# Altium schematic sheet 04_POWER.SchDoc(04_POWER)
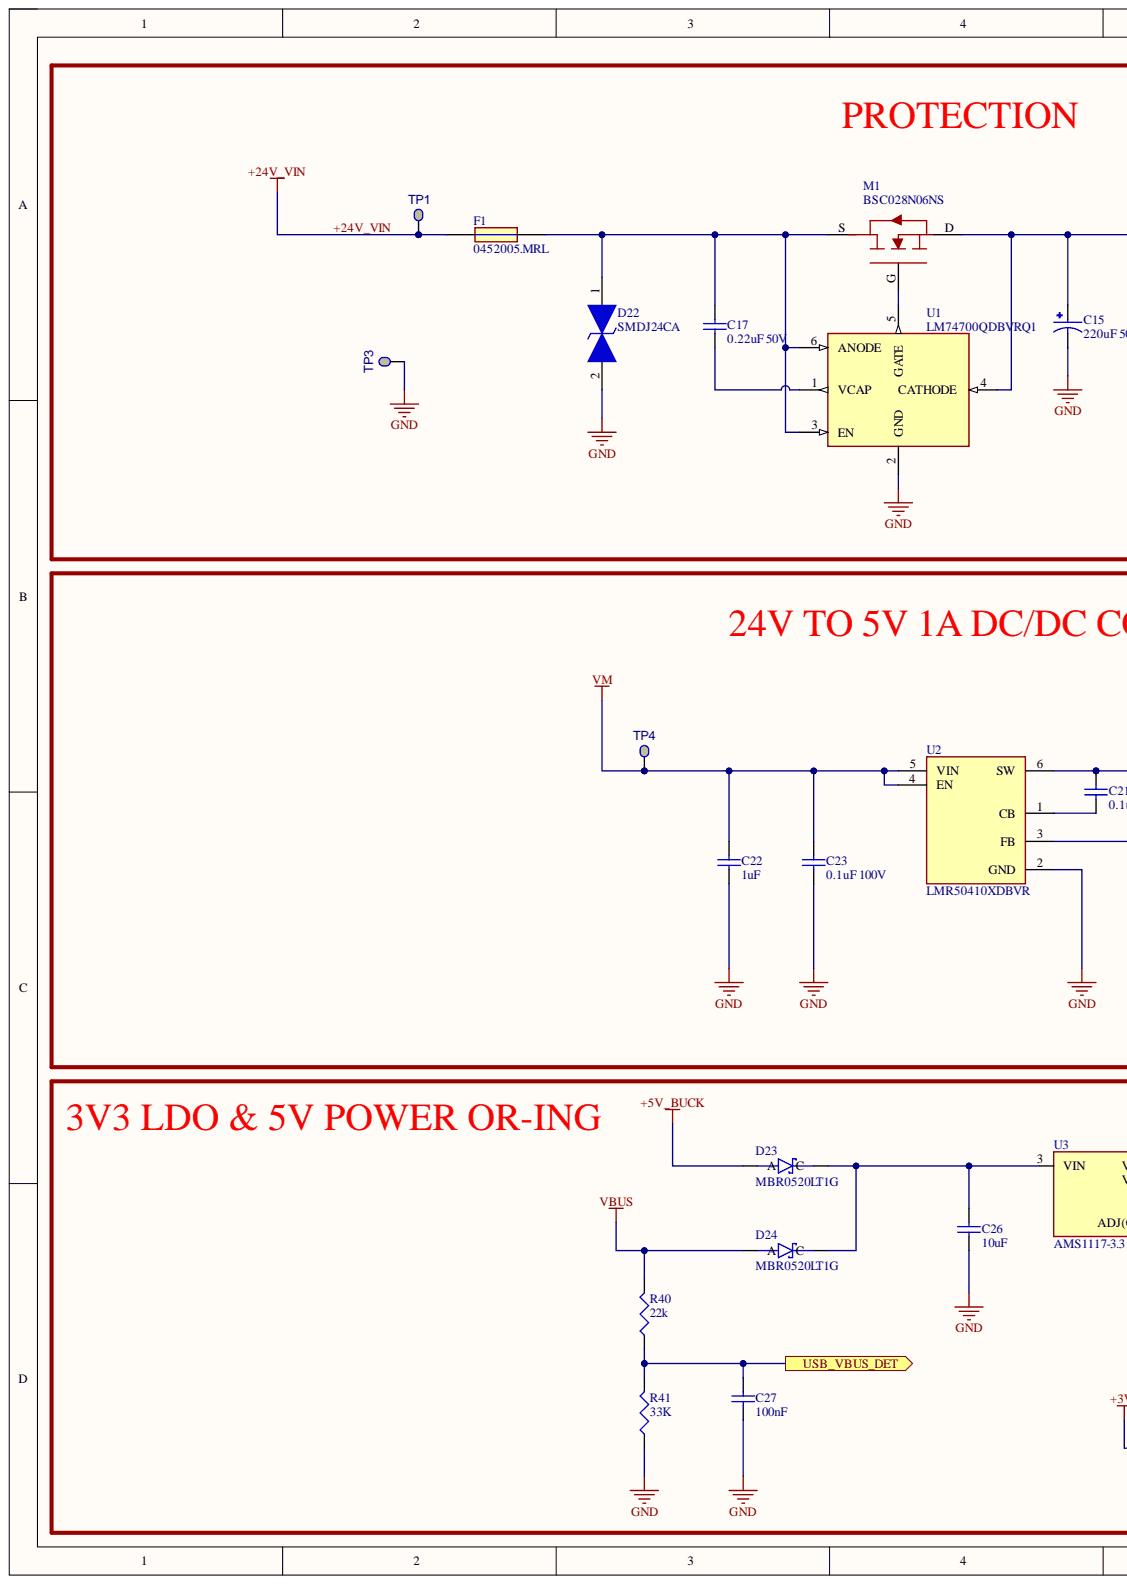
[diagram p0: 04_POWER.SchDoc, left]
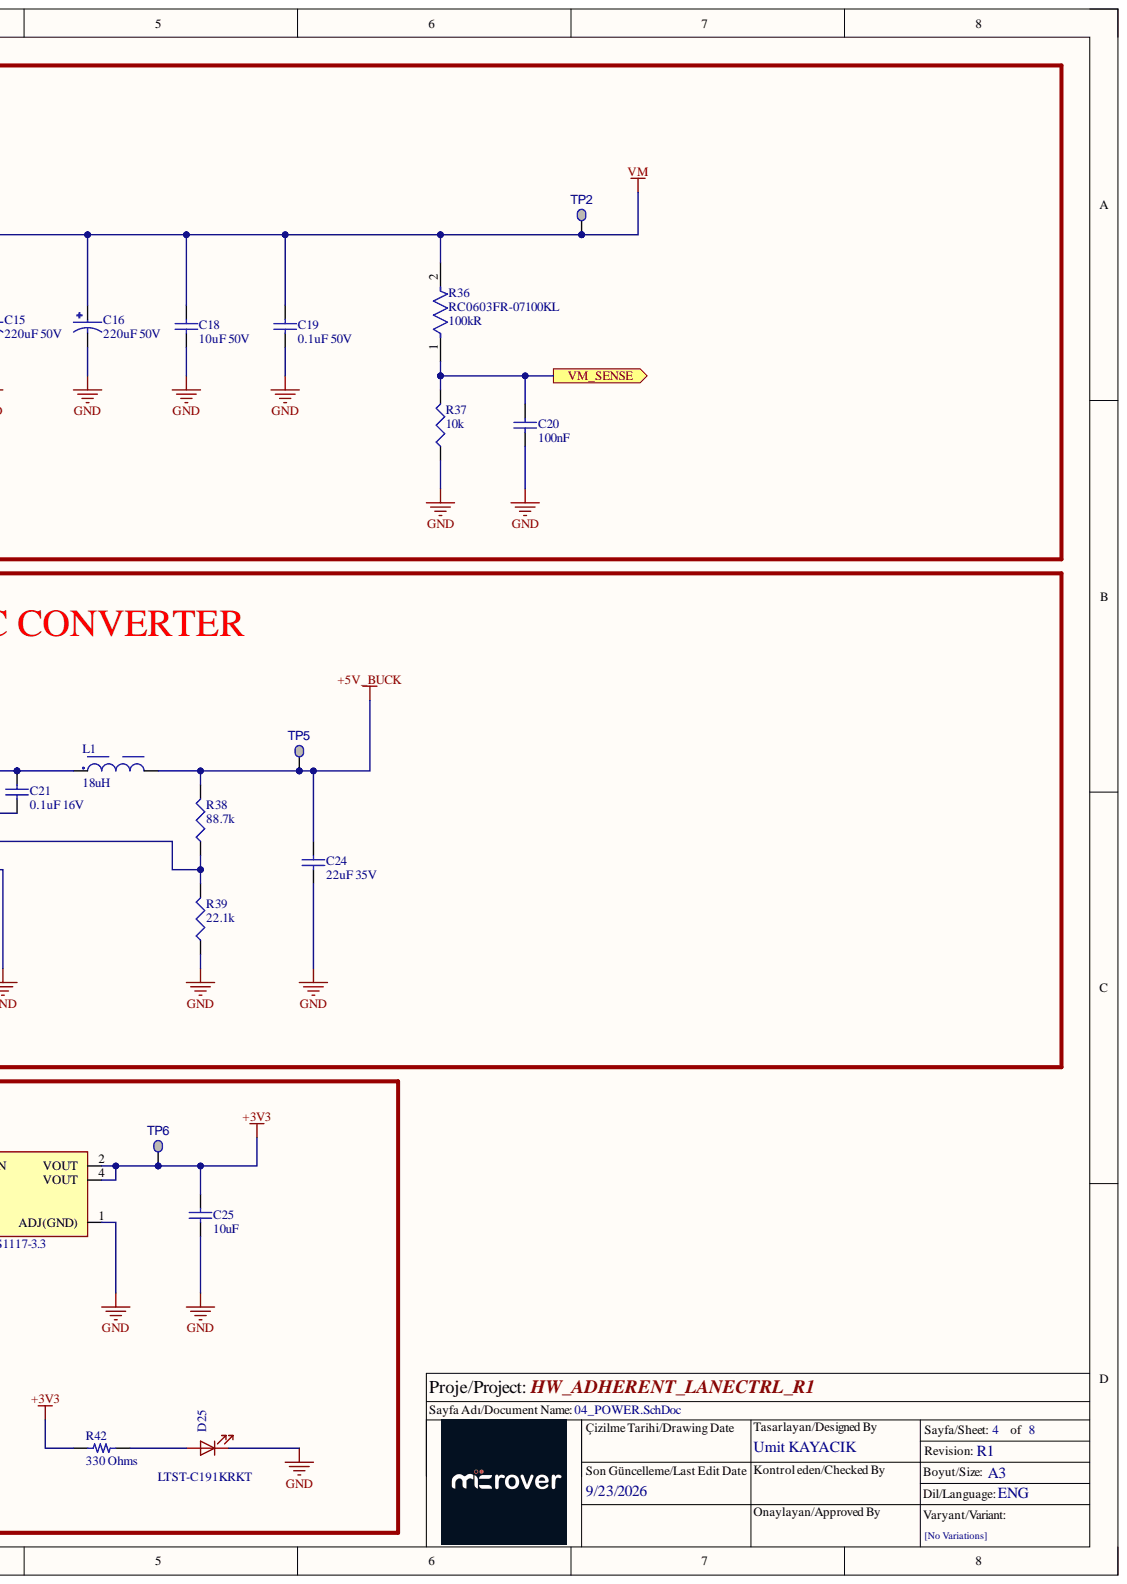
[diagram p1: 04_POWER.SchDoc, right]

## Parts
C15  220uF 50V  [CAP_EEEFT1H221AV]
C16  220uF 50V  [CAP_EEEFT1H221AV]
C17  value 0.22uF 50V  [SMC0603]  (hidden comment: CAP CER 0.22UF 50V X7R 0603)
C18  value 10uF 50V  [SMC1206]  (hidden comment: CAP CER 10UF 50V X5R 1206)
C19  value 0.1uF 50V  [SMC0603]  (hidden comment: CAP CER 0.1UF 50V X7R 0603)
C20  value 100nF  [CAP0603]  (hidden comment: 100nF 25V)
C21  0.1uF 16V  [CAP0603]
C22  1uF  [CAP0603]
C23  0.1uF 100V  [CAP0603]
C24  22uF 35V  [CAP1206]
C25  10uF  [CAP0603]
C26  10uF  [CAP0603]
C27  value 100nF  [CAP0603]  (hidden comment: 100nF 25V)
D22  SMDJ24CA  [DIONM9059X262N]
D23  MBR0520LT1G  [SOD3716X135N]
D24  MBR0520LT1G  [SOD3716X135N]
D25  LTST-C191KRKT  [LED_SMD:LED_0603_1608Metric]
F1  0452005.MRL  [FUSC6127X294N]
L1  18uH  [WE-LQS_6045]
M1  BSC028N06NS  [BSC028N06NS]
R36  RC0603FR-07100KL  Resistance: 100kR  [FP-RC0603-0_55-MFG]
R37  value 10k  [RES0603]  (hidden comment: RES 10K OHM 1% 1/8W 0603)
R38  88.7k  [RES0603]
R39  22.1k  [RES0603]
R40  value 22k  [SMR0603]  (hidden comment: RES 22K OHM 1% 1/10W 0603)
R41  value 33K  [SMR0603]  (hidden comment: RES 33K OHM 1% 1/10W 0603)
R42  330 Ohms  [RES 0603_1608]
TP1  Testpoint  [SMD_Ø1.5mm]  (value not drawn)  (no BOM)
TP2  Testpoint  [SMD_Ø1.5mm]  (value not drawn)  (no BOM)
TP3  Testpoint  [SMD_Ø1.5mm]  (value not drawn)  (no BOM)
TP4  Testpoint  [SMD_Ø1.5mm]  (value not drawn)  (no BOM)
TP5  Testpoint  [SMD_Ø1.5mm]  (value not drawn)  (no BOM)
TP6  Testpoint  [SMD_Ø1.5mm]  (value not drawn)  (no BOM)
U1  LM74700QDBVRQ1  [SOT95P280X145-6N/LM74700QDBVRQ1]
U2  LMR50410XDBVR  [SOT95P280X145-6N/]
U3  AMS1117-3.3  [SOT-223-4_L6.5-W3.5-P2.30-LS7.0-BR]

## Ports
USB_VBUS_DET, VM_SENSE

## Nets
+3V3: C25.2 R42.1 TP6.1 U3.2(VOUT) U3.4(VOUT)
+5V_BUCK: C24.2 D23.A L1.2 R38.2 TP5.1
GND: C15.2 C16.2 C18.1 C19.1 C20.1 C22.1 C23.1 C24.1 C25.1 C26.1 C27.1 D22.2 D25.2 R37.1 R39.1 R41.1 TP3.1 U1.2(GND) U2.2(GND) U3.1(ADJ(GND))
VBUS: D24.A R40.2
VM: C15.1 C16.1 C18.2 C19.2 C22.2 C23.2 M1.D R36.2 TP2.1 TP4.1 U1.4(CATHODE) U2.4(EN) U2.5(VIN)
+24V_VIN: F1.1 TP1.1
USB_VBUS_DET: C27.2 R40.1 R41.2 ; port USB_VBUS_DET
VM_SENSE: C20.2 R36.1 R37.2 ; port VM_SENSE
NetC17_1: C17.1 U1.1(VCAP)
NetC17_2: C17.2 D22.1 F1.2 M1.S U1.3(EN) U1.6(ANODE)
NetC21_1: C21.1 U2.1(CB)
NetC21_2: C21.2 L1.1 U2.6(SW)
NetC26_2: C26.2 D23.C D24.C U3.3(VIN)
NetD25_1: D25.1 R42.2
NetM1_G: M1.G U1.5(GATE)
NetR38_1: R38.1 R39.2 U2.3(FB)

## Library data of the parts
C15 C16 = EEEFT1H221AV: 220 µF 50 V Aluminum Electrolytic Capacitors Radial, Can - SMD 180mOhm @ 100kHz 2000 Hrs @ 105°C; Manufacturer Part Number: EEE-FT1H221AV; MF: Panasonic Electronic Components; Availability: In Stock; Check_prices: https://www.snapeda.com/parts/EEE-FT1H221AV/Panasonic/view-part/5517810/?ref=eda; Component Kind: Standard; Package: RADIAL-2 Panasonic; Price: None; SnapEDA_Link: https://www.snapeda.com/parts/EEE-FT1H221AV/Panasonic/view-part/5517810/?ref=snap; Library Name: HW_ADHERENT_LANECTRL_R1.SchLib
C17 = CAP0603_0.22UF_50V: 0.22 µF ±10% 50V Ceramic Capacitor X7R 0603 (1608 Metric); Manufacturer Part Number: GCM188R71H224KA64D; Order Code: DIGI: 490-5402-2-ND; Component Kind: Standard; Value: 0.22uF 50V; Library Name: HW_ADHERENT_LANECTRL_R1.SchLib
C18 = CAP1206_10UF_50V: 10 µF ±10% 50V Ceramic Capacitor X5R 1206 (3216 Metric); LCSC Manufacturer Part Number: CL31A106KBHNNNE; Order Code: Digi: 1276-2876-2-ND; Component Kind: Standard; LCSC Part Number: C13585; Manufacturer Part Number: CL31A106KBHNNNE; Library Name: HW_ADHERENT_LANECTRL_R1.SchLib
C19 = CAP0603_0.1UF_50V_2: 0.1 µF ±10% 50V Ceramic Capacitor X7R 0603 (1608 Metric); LCSC Manufacturer Part Number: CL10B104KB8NNNC; Manufacturer Part Number: CL10B104KB8NNNL; Order Code: DIGI:1276-CL10B104KB8NNNLTR-ND; Sirius Stock Code: A063-AGC10300001226; Component Kind: Standard; LCSC Part Number: C1591; Library Name: HW_ADHERENT_LANECTRL_R1.SchLib
C20 C27 = CAP0603_100nF: 0.1 µF ±10% 50V Ceramic Capacitor X7R 0603 (1608 Metric); Manufacturer Part Number: CL10B104KA8NNNC; Component Kind: Standard; LCSC Part Number: C1590; Library Name: HW_ADHERENT_LANECTRL_R1.SchLib
C21 = C0603C104M4RACTU: 0.1 µF ±20% 16V Ceramic Capacitor X7R 0603 (1608 Metric); Manifacturer: KEMET; Component Kind: Standard; Supplier: Digi; 399-C0603C104M4RACTUDKR-ND; Library Name: HW_ADHERENT_LANECTRL_R1.SchLib
C22 = MSASU168SB5105MTNA01: CAP CER 1UF 50V X5R 0603; Manufacturer: Taiyo Yuden; Component Kind: Standard; Supplier: 587-MSASU168SB5105MTNA01DKR-ND; Library Name: HW_ADHERENT_LANECTRL_R1.SchLib
C23 = GRM188R72A104KA35D: CAP CER 0.1UF 100V X7R 0603; Manifacturer: Murata Electronics; Component Kind: Standard; Supplier: Digi; 490-3285-6-ND; Library Name: HW_ADHERENT_LANECTRL_R1.SchLib
C24 = CAP1206_22uF_35V: 22 µF ±20% 35V Ceramic Capacitor X5R 1206 (3216 Metric); Manufacturer Part Number: C3216X5R1V226M160AC; Order Code: DIGI : 445-180362-1-ND; Component Kind: Standard; Value: 22uF 35V; Library Name: HW_ADHERENT_LANECTRL_R1.SchLib
C25 C26 = CAP0603_10uF_25V: 10 µF ±10% 25V Ceramic Capacitor X5R 0603 (1608 Metric); Manufacturer Part Number: GRM188R61E106KA73J; Order Code: Digi; 490-GRM188R61E106KA73JDKR-ND; Component Kind: Standard; Value: 10uF; Library Name: HW_ADHERENT_LANECTRL_R1.SchLib
D22 = SMDJ24CA: 38.9V Clamp 77.1A Ipp Tvs Diode Surface Mount DO-214AB (SMCJ); Manufacturer: Littelfuse Inc.; Component Kind: Standard; MPN: SMDJ24CA; Library Name: HW_ADHERENT_LANECTRL_R1.SchLib
D23 D24 = MBR0520LT1G: Diode 20 V 500mA Surface Mount SOD-123; Component Kind: Standard; Library Name: HW_ADHERENT_LANECTRL_R1.SchLib
D25 = LED_1: LED RED CLEAR SMD; Manufacturer: Lite-On Inc.; Component Kind: Standard; Manufacturer Part Number: LTST-C191KRKT; Supplier Part Number: 160-1447-1-ND; Value: LTST-C191KRKT; Library Name: HW_ADHERENT_LANECTRL_R1.SchLib
F1 = 0452005.MRL: FUSE BRD MNT 5A 125VAC/VDC 2SMD; Manufacturer: Littelfuse; Component Kind: Standard; Supplier: Digi; F2607DKR-ND; Library Name: HW_ADHERENT_LANECTRL_R1.SchLib
L1 = 74404064180: 18 µH Semi-Shielded Drum Core, Wirewound Inductor 2.2 A 81mOhm Nonstandard; Component Kind: Standard; Supplier: 732-5553-6-ND; Library Name: HW_ADHERENT_LANECTRL_R1.SchLib
M1 = BSC028N06NS: MOSFET'ler N-Ch 60V 100A TDSON-8 OptiMOS 3; Component Kind: Standard; Library Name: HW_ADHERENT_LANECTRL_R1.SchLib
R36 = CMP-2100-03662-2: RES SMD 100K OHM 1% 1/10W 0603; Max Power Dissipation: 100mW; Temperature Coefficient: 100ppm/°C; Voltage Rating: 50V; Working Voltage: 75V; Case Code (Imperial): 0603; Case Code (Metric): 1608; Case/Package: 0603; Component Kind: Standard; Construction: Rectangular; Depth: 0.8mm; Features: Moisture Resistant; Height: 0.45mm; Lead Free: Lead Free; Length: 1.6mm; Max Operating Temperature: 155°C; Military Standard: Not; Min Operating Temperature: -55°C; Number of Pins: 2; Number of Terminations: 2; Packaging: Tape and Reel; Power Rating: 100mW; REACH SVHC: No SVHC; Resistance Tolerance: 1%; Resistor Type: Thick Film; RoHS Compliant: Yes; Series: RC; Technology: THICK FILM; Termination: SMD/SMT; Tolerance: 1%; Voltage Rating (DC): 50V; Width: 0.8mm; Library Name: HW_ADHERENT_LANECTRL_R1.SchLib
R37 = RES0603_10K_%1_1: 10 kOhms ±1% 0.125W, 1/8W Chip Resistor 0603 (1608 Metric) Anti-Sulfur Thin Film; LCSC Manufacturer Part Number: MCT06030C1002FP500; Manufacturer Part Number: RNCP0603FTD10K0; Order Code: Digi: RNCP0603FTD10K0TR-ND; Component Kind: Standard; LCSC Part Number: C161803; Library Name: HW_ADHERENT_LANECTRL_R1.SchLib
R38 = CRCW060388K7FKEA: 88.7 kOhms ±1% 0.125W, 1/8W Chip Resistor 0603 (1608 Metric) Automotive AEC-Q200 Thick Film; Component Kind: Standard; Library Name: HW_ADHERENT_LANECTRL_R1.SchLib
R39 = RC0603FR-0722K1L: 22.1 kOhms ±1% 0.1W, 1/10W Chip Resistor 0603 (1608 Metric) Moisture Resistant Thick Film; Component Kind: Standard; Library Name: HW_ADHERENT_LANECTRL_R1.SchLib
R40 = RES0603_22K_%1: 10 kOhms ±1% 0.1W, 1/10W Chip Resistor 0603 (1608 Metric) Moisture Resistant Thick Film; LCSC Manufacturer Part Number: RC0603FR-0722KL; Order Code: Digi: 311-22.0KHRTR-ND; Component Kind: Standard; LCSC Part Number: C114065; Manufacturer Part Number: RC0603FR-0722KL; Library Name: HW_ADHERENT_LANECTRL_R1.SchLib
R41 = RES0603_33K_%1: 33 kOhms ±1% 0.1W, 1/10W Chip Resistor 0603 (1608 Metric) Moisture Resistant Thick Film; LCSC Manufacturer Part Number: RC0603FR-0733KL; Order Code: Digi: 311-33.0KHRTR-ND; Component Kind: Standard; LCSC Part Number: C126359; Manufacturer Part Number: RC0603FR-0733KL; Library Name: HW_ADHERENT_LANECTRL_R1.SchLib
R42 = CRGH0603F330R: RES SMD 330 OHM 1% 1/5W 0603; Manufacturer: TE Connectivity Passive Product; Power (Watts): 0.2W, 1/5W; Temperature Coefficient: ±100ppm/°C; Component Kind: Standard; ComponentLink1Description: Datasheet; ComponentLink1URL: https://www.te.com/commerce/DocumentDelivery/DDEController?Action=srchrtrv&DocNm=1773204-1&DocType=DS&DocLang=English; ComponentLink2Description: DigiKey Link; ComponentLink2URL: https://www.digikey.com/en/products/detail/te-connectivity-passive-product/CRGH0603F330R/5586961; Composition: Thick Film; Device Package: 0603 (1608 Metric); Height - Seated (Max): 0.022" (0.55mm); LastUpdated: 2/9/2024 8:43:33 PM; Lifecycle Status: Active; Minimum Order: 1; Number of Terminations: 2; Operating Temperature: -55°C ~ 155°C; Package / Case: 0603 (1608 Metric); Packaging: TapeAndReel; Part Number: CRGH0603F330R; Part Status: Active; PartId: 5733; Price: 0.01; Resistance: 330 Ohms; Series: CRGH, Neohm; Size / Dimension: 0.063" L x 0.031" W (1.60mm x 0.80mm); Supplier 1: DigiKey; Supplier Device Package: 0603; Supplier Part Number 1: A129541CT-ND; Tolerance: ±1%; Value: 330 Ohms; Library Name: HW_ADHERENT_LANECTRL_R1.SchLib
TP1-TP6 = TEST PAD SMT 10MIL X 20MIL: Description: Testpoint; DNP: DNP; Value: Testpoint; Library Name: HW_ADHERENT_LANECTRL_R1.SchLib
U1 = LM74700QDBVRQ1: OR Controller N+1 ORing Controller N-Channel 1:1 SOT-23-6; Component Kind: Standard; Library Name: HW_ADHERENT_LANECTRL_R1.SchLib
U2 = LMR50410XDBVR: Buck Switching Regulator IC Positive Adjustable 1V 1 Output 1A SOT-23-6; Component Kind: Standard; MPN: LMR50410XDBVR; Supplier: 296-LMR50410XDBVRDKR-ND; Library Name: HW_ADHERENT_LANECTRL_R1.SchLib
U3 = AMS1117-3.3: Linear Voltage Regulator IC Positive Fixed 1 Output 1A SOT-223-3L; Manufacturer: UMW; Component Kind: Standard; Supplier: C347222; Library Name: HW_ADHERENT_LANECTRL_R1.SchLib
